# Altium schematic sheet 07-KSZ9031_1G_BASET_XCVR.SchDoc(07-KSZ9031_1G_BASET_XCVR)
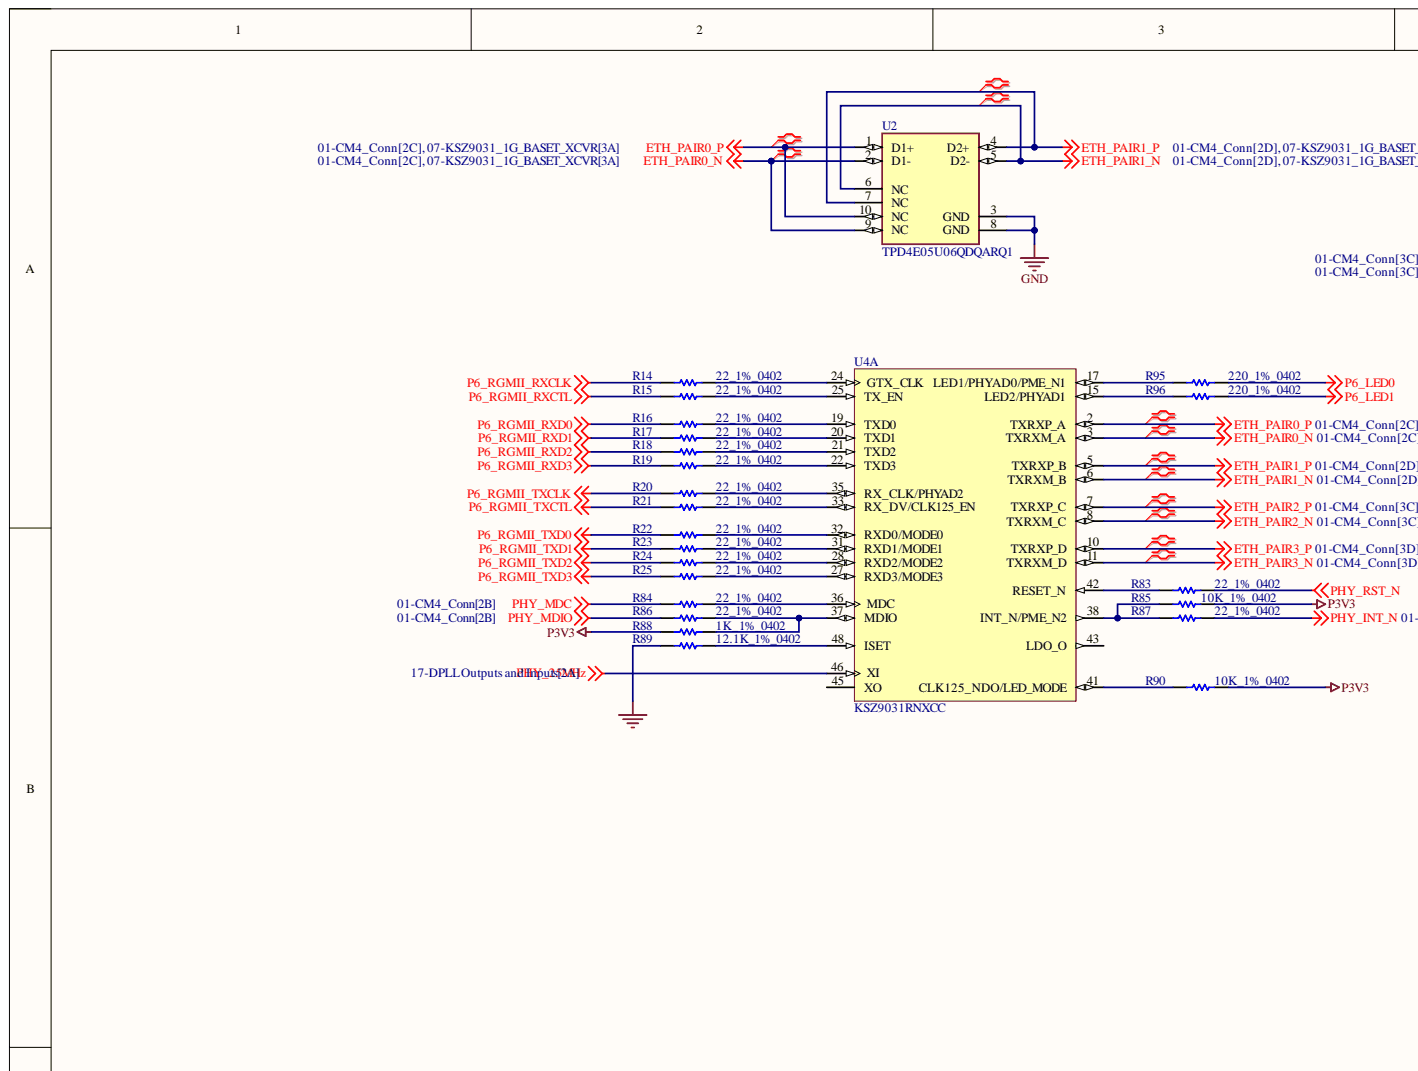
[diagram p0: 07-KSZ9031_1G_BASET_XCVR.SchDoc, top left]
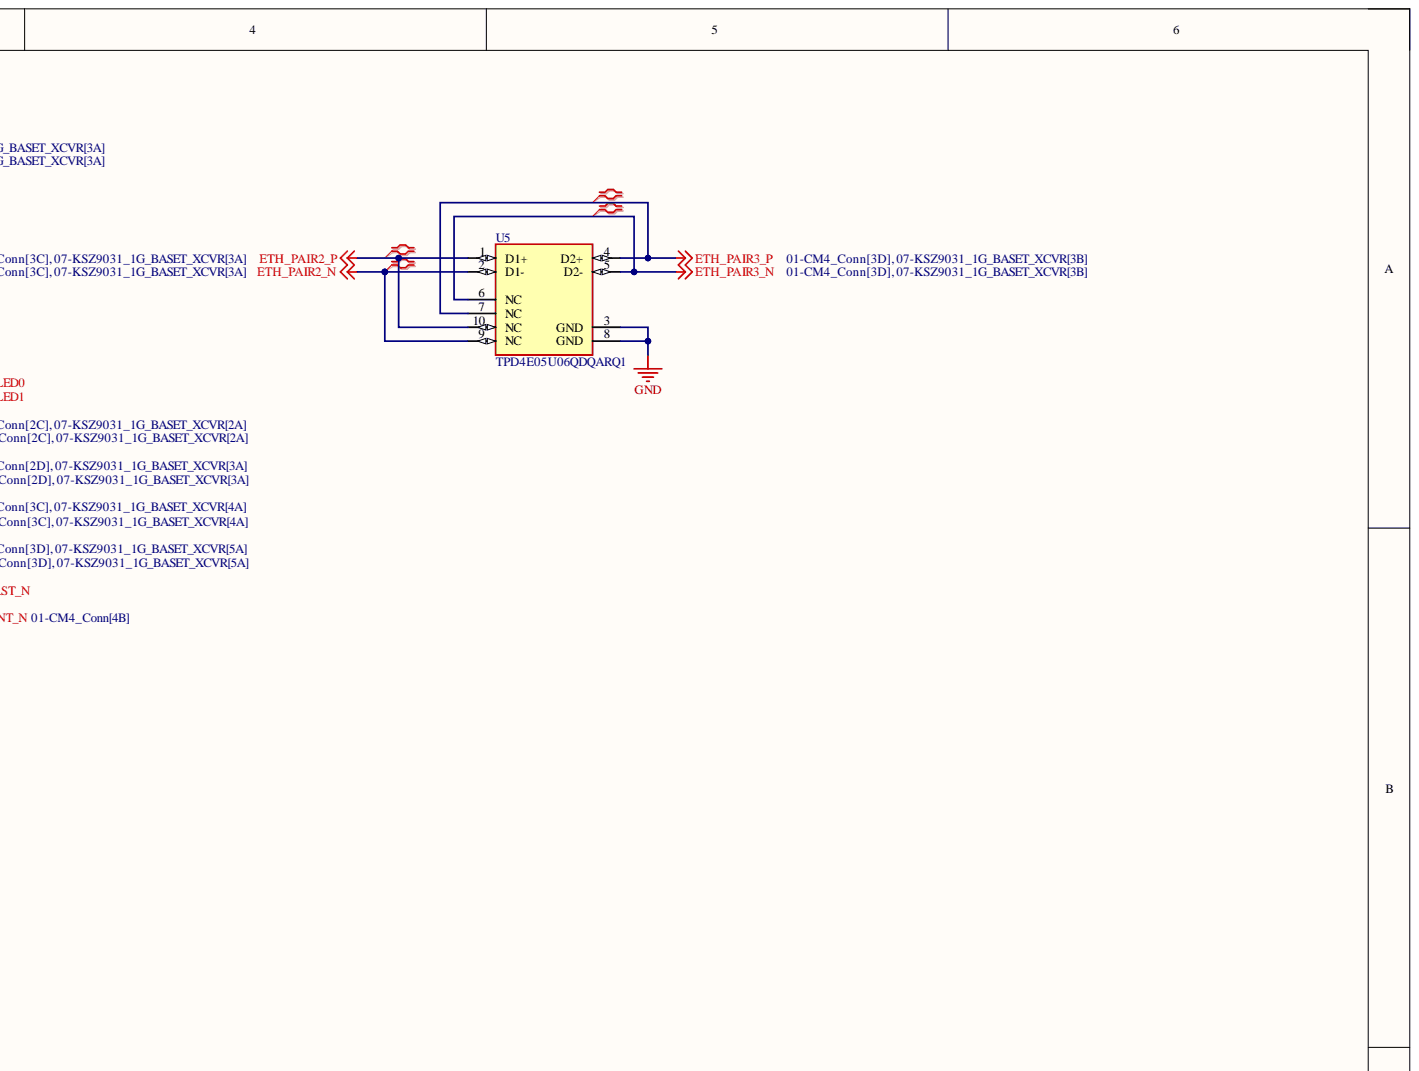
[diagram p1: 07-KSZ9031_1G_BASET_XCVR.SchDoc, top right]
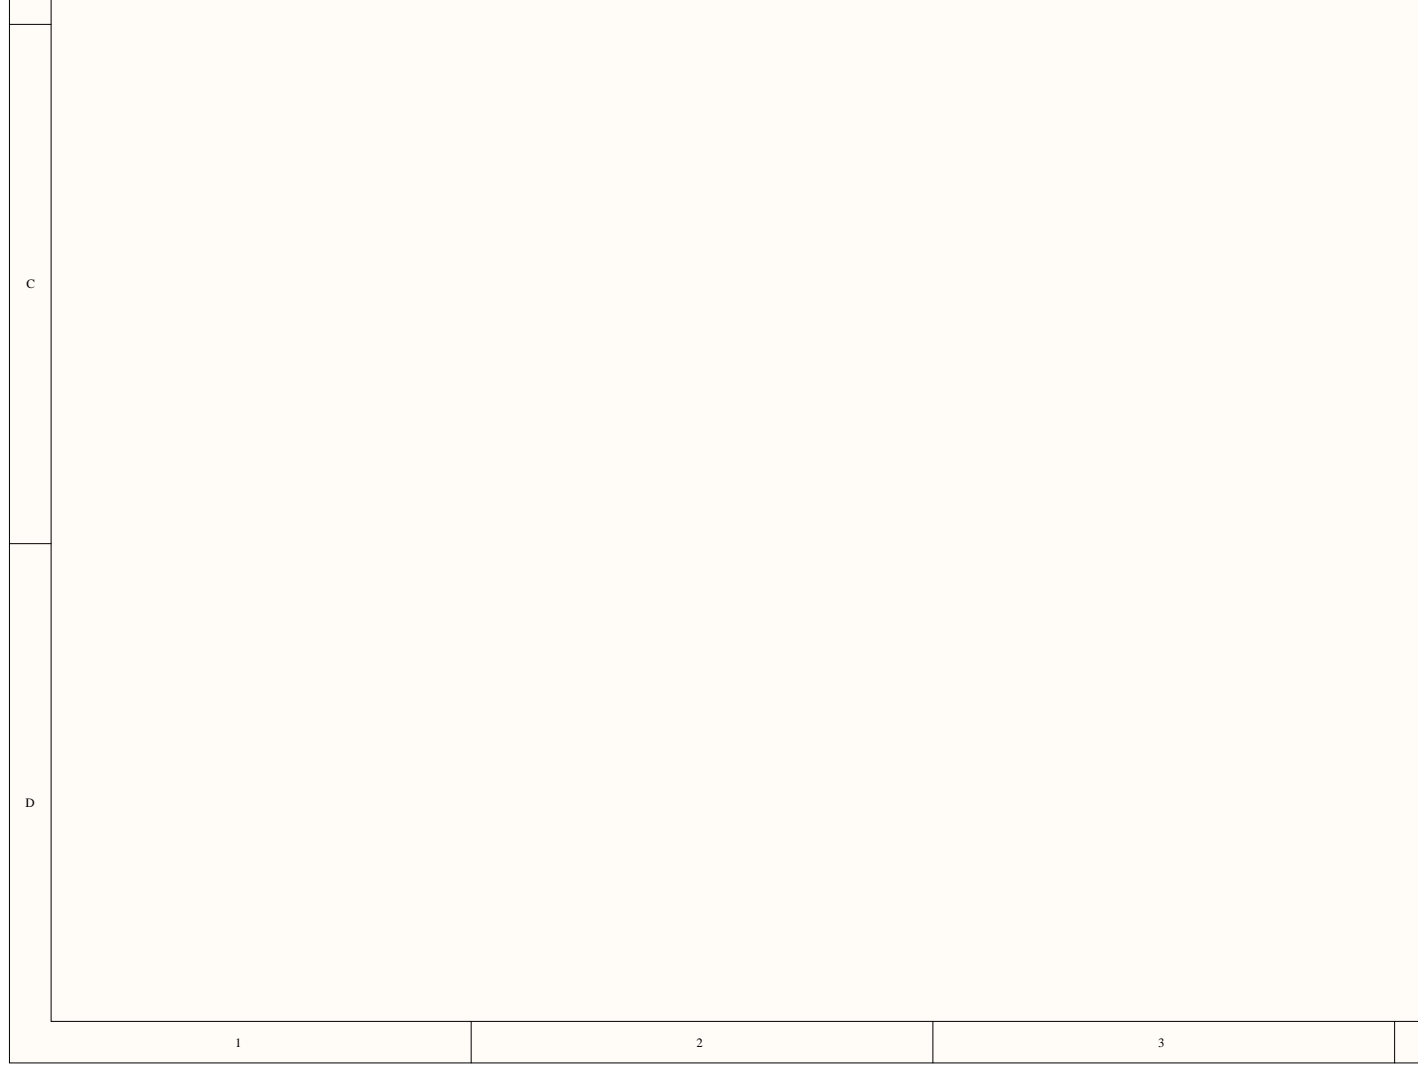
[diagram p2: 07-KSZ9031_1G_BASET_XCVR.SchDoc, bottom left]
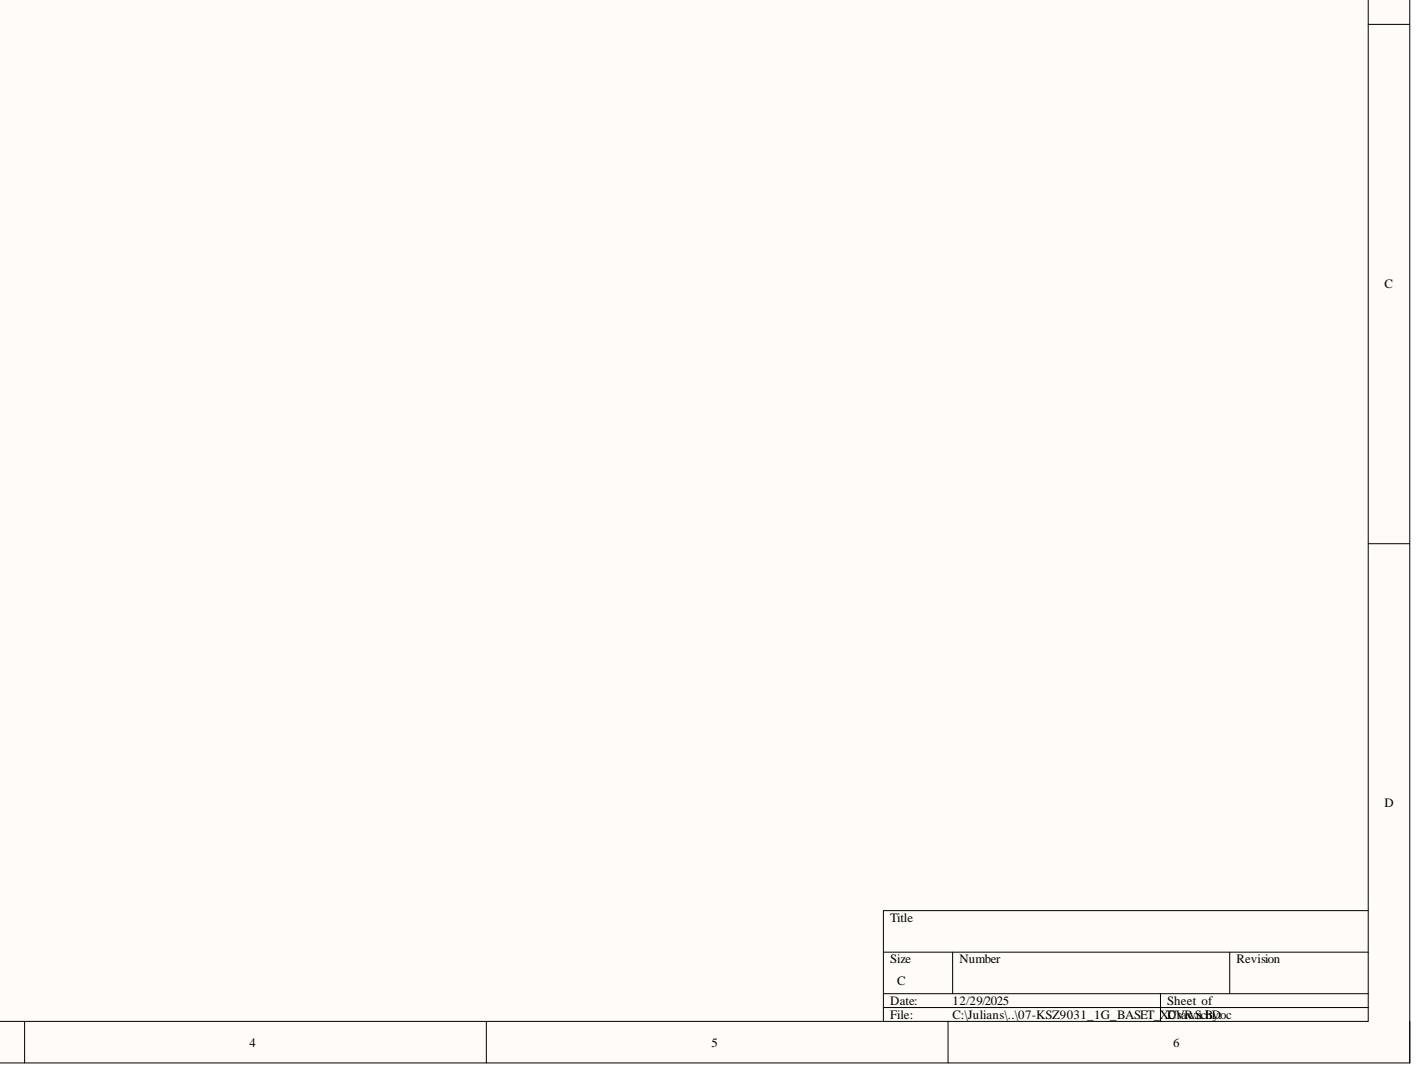
[diagram p3: 07-KSZ9031_1G_BASET_XCVR.SchDoc, bottom right]

## Parts
R14  22_1%_0402  [RESC1005X40X25ML05T10]
R15  22_1%_0402  [RESC1005X40X25ML05T10]
R16  22_1%_0402  [RESC1005X40X25ML05T10]
R17  22_1%_0402  [RESC1005X40X25ML05T10]
R18  22_1%_0402  [RESC1005X40X25ML05T10]
R19  22_1%_0402  [RESC1005X40X25ML05T10]
R20  22_1%_0402  [RESC1005X40X25ML05T10]
R21  22_1%_0402  [RESC1005X40X25ML05T10]
R22  22_1%_0402  [RESC1005X40X25ML05T10]
R23  22_1%_0402  [RESC1005X40X25ML05T10]
R24  22_1%_0402  [RESC1005X40X25ML05T10]
R25  22_1%_0402  [RESC1005X40X25ML05T10]
R83  22_1%_0402  [RESC1005X40X25ML05T10]
R84  22_1%_0402  [RESC1005X40X25ML05T10]
R85  10K_1%_0402  [RESC1005X40X25NL10T10]
R86  22_1%_0402  [RESC1005X40X25ML05T10]
R87  22_1%_0402  [RESC1005X40X25ML05T10]
R88  1K_1%_0402  [RESC1005X40X25ML05T10]
R89  12.1K_1%_0402  [RESC1005X40X25NL10T10]
R90  10K_1%_0402  [RESC1005X40X25NL10T10]
R95  220_1%_0402  [RESC1005X40X25NL05T10]
R96  220_1%_0402  [RESC1005X40X25NL05T10]
U2  TPD4E05U06QDQARQ1  [FP-DQA0010A-MFG]
U4  KSZ9031RNXCC  [MICL-QFN-48_V]  (part A)
U5  TPD4E05U06QDQARQ1  [FP-DQA0010A-MFG]

## Nets
ETH_PAIR0_N: U2.2(D1-) U2.9(NC) U4.3(TXRXM_A)
ETH_PAIR0_P: U2.1(D1+) U2.10(NC) U4.2(TXRXP_A)
ETH_PAIR1_N: U2.5(D2-) U2.6(NC) U4.6(TXRXM_B)
ETH_PAIR1_P: U2.4(D2+) U2.7(NC) U4.5(TXRXP_B)
ETH_PAIR2_N: U4.8(TXRXM_C) U5.2(D1-) U5.9(NC)
ETH_PAIR2_P: U4.7(TXRXP_C) U5.1(D1+) U5.10(NC)
ETH_PAIR3_N: U4.11(TXRXM_D) U5.5(D2-) U5.6(NC)
ETH_PAIR3_P: U4.10(TXRXP_D) U5.4(D2+) U5.7(NC)
GND: R89.1 U2.3(GND) U2.8(GND) U5.3(GND) U5.8(GND)
P3V3: R85.2 R88.1 R90.2
P6_LED0: R95.2
P6_LED1: R96.2
P6_RGMII_RXCLK: R14.1
P6_RGMII_RXCTL: R15.1
P6_RGMII_RXD0: R16.1
P6_RGMII_RXD1: R17.1
P6_RGMII_RXD2: R18.1
P6_RGMII_RXD3: R19.1
P6_RGMII_TXCLK: R20.1
P6_RGMII_TXCTL: R21.1
P6_RGMII_TXD0: R22.1
P6_RGMII_TXD1: R23.1
P6_RGMII_TXD2: R24.1
P6_RGMII_TXD3: R25.1
PHY_25MHz: U4.46(XI)
PHY_INT_N: R87.2
PHY_MDC: R84.1
PHY_MDIO: R86.1
PHY_RST_N: R83.2
NetR14_2: R14.2 U4.24(GTX_CLK)
NetR15_2: R15.2 U4.25(TX_EN)
NetR16_2: R16.2 U4.19(TXD0)
NetR17_2: R17.2 U4.20(TXD1)
NetR18_2: R18.2 U4.21(TXD2)
NetR19_2: R19.2 U4.22(TXD3)
NetR20_2: R20.2 U4.35(RX_CLK/PHYAD2)
NetR21_2: R21.2 U4.33(RX_DV/CLK125_EN)
NetR22_2: R22.2 U4.32(RXD0/MODE0)
NetR23_2: R23.2 U4.31(RXD1/MODE1)
NetR24_2: R24.2 U4.28(RXD2/MODE2)
NetR25_2: R25.2 U4.27(RXD3/MODE3)
NetR83_1: R83.1 U4.42(RESET_N)
NetR84_2: R84.2 U4.36(MDC)
NetR85_1: R85.1 R87.1 U4.38(INT_N/PME_N2)
NetR86_2: R86.2 R88.2 U4.37(MDIO)
NetR89_2: R89.2 U4.48(ISET)
NetR90_1: R90.1 U4.41(CLK125_NDO/LED_MODE)
NetR95_1: R95.1 U4.17(LED1/PHYAD0/PME_N1)
NetR96_1: R96.1 U4.15(LED2/PHYAD1)

Not connected: U4 43(LDO_O) 45(XO)

## Library data of the parts
R14-R25 R83 R84 R86 R87 = CMP-2002-07182-1: Manufacturer: Yageo / Phycomp; Part Number: RC0402FR-1322RL; Component Kind: Standard; Library Name: Altium Content Vault
R85 R90 = CMP-2002-04694-1: Manufacturer: Bourns; Part Number: CR0402-FX-1002GLF; Component Kind: Standard; Library Name: Altium Content Vault
R88 = CMP-2002-06478-1: Manufacturer: Multicomp; Part Number: MCMR04X1001FTL; Component Kind: Standard; Library Name: Altium Content Vault
R89 = CMP-2002-05926-1: Manufacturer: Multicomp; Part Number: MC00625W0402112K1; Component Kind: Standard; Library Name: Altium Content Vault
R95 R96 = CMP-2002-07959-1: Manufacturer: Yageo / Phycomp; Part Number: RC0402JR-07220RL; Component Kind: Standard; Library Name: Altium Content Vault
U2 U5 = CMP-04985-000004-1: TVS DIODE 5.5V 14V 10USON; Manufacturer: Texas Instruments; Automotive Grade: Grade 1; Breakdown Voltage: 6.4V; Capacitance: 0.5pF; Direction: Unidirectional; Interface: USB,Ethernet,LVDS,Other; Number Of Channels: 4; Peak Pulse Current: 2.5A; Peak Pulse Power: 2.5W; Standoff Height: 0mm; Value: 0.5pF; Ambient Temperature Range High: +125°C; Ambient Temperature Range Low: -40°C; Automotive: Yes; Category: IC; Clamping Voltage: 14V; Component Kind: Standard; ComponentLink2Description: Datasheet; ComponentLink2URL: http://www.ti.com/lit/ds/symlink/tpd4e05u06-q1.pdf; Device Class L1: Circuit Protection; Device Class L2: ESD and Circuit Protection ICs; Device Class L3: unset; Digikey Description: TVS DIODE 5.5V 14V 10USON; Height: 0.55mm; Lead Free: Yes; Library Name: Altium Content Vault; Manufacturer Part Number: TPD4E05U06QDQARQ1; Mouser Description: Automotive 4-Channel ESD Protection Solution for SuperSpeed (up to 5 Gbps) Interface 10-USON -40 to 125; Octopart: https://octopart.com/tpd4e05u06qdqarq1-texas+instruments-48523459; Operating Supply Voltage: 5.5V; Package: DQA0010A; Reverse Standoff Voltage: 5.5V; RoHS: TRUE; Temperature: -40°C to +125°C; Temperature Range High: +125°C; Temperature Range Low: -40°C
U4 = CMP-1337-00003-2: Gigabit Ethernet Transceiver with RGMII Support, 1.14 to 1.26 V, 0 to 70 degC, 48-Pin QFN, RoHS; Manufacturer: Micrel; Drivers: 4; ILED: 8 mA Typ.; Output Tri-State Leakage: 10 uA Max.; VSET@R(ISET): 12.1 KOhm=1.2 V Typ.; Component Kind: Standard; ComponentLink1Description: Manufacturer URL; ComponentLink1URL: http://www.micrel.com/; ComponentLink2Description: Datasheet; ComponentLink2URL: http://www.micrel.com/_PDF/Ethernet/datasheets/KSZ9031RNX.pdf; ComponentLink3Description: Package Specification; ComponentLink3URL: http://www.micrel.com/_PDF/Ethernet/datasheets/KSZ9031RNX.pdf; DatasheetVersion: Rev. 2.1; DVDDL: 1.14 to 1.26 V; Library Name: Altium Content Vault; Mounting Technology: Surface Mount; PackageDescription: 48-Pin Quad Flat Non-Lead Package, Body 7 x 7 mm, Pitch 0.5 mm; PartNumber: KSZ9031RNXCC; Receivers: 4; RoHS: TRUE; Temperature Range: 0 to 70 degC
